# Altium schematic sheet Energia.SchDoc(Energia)
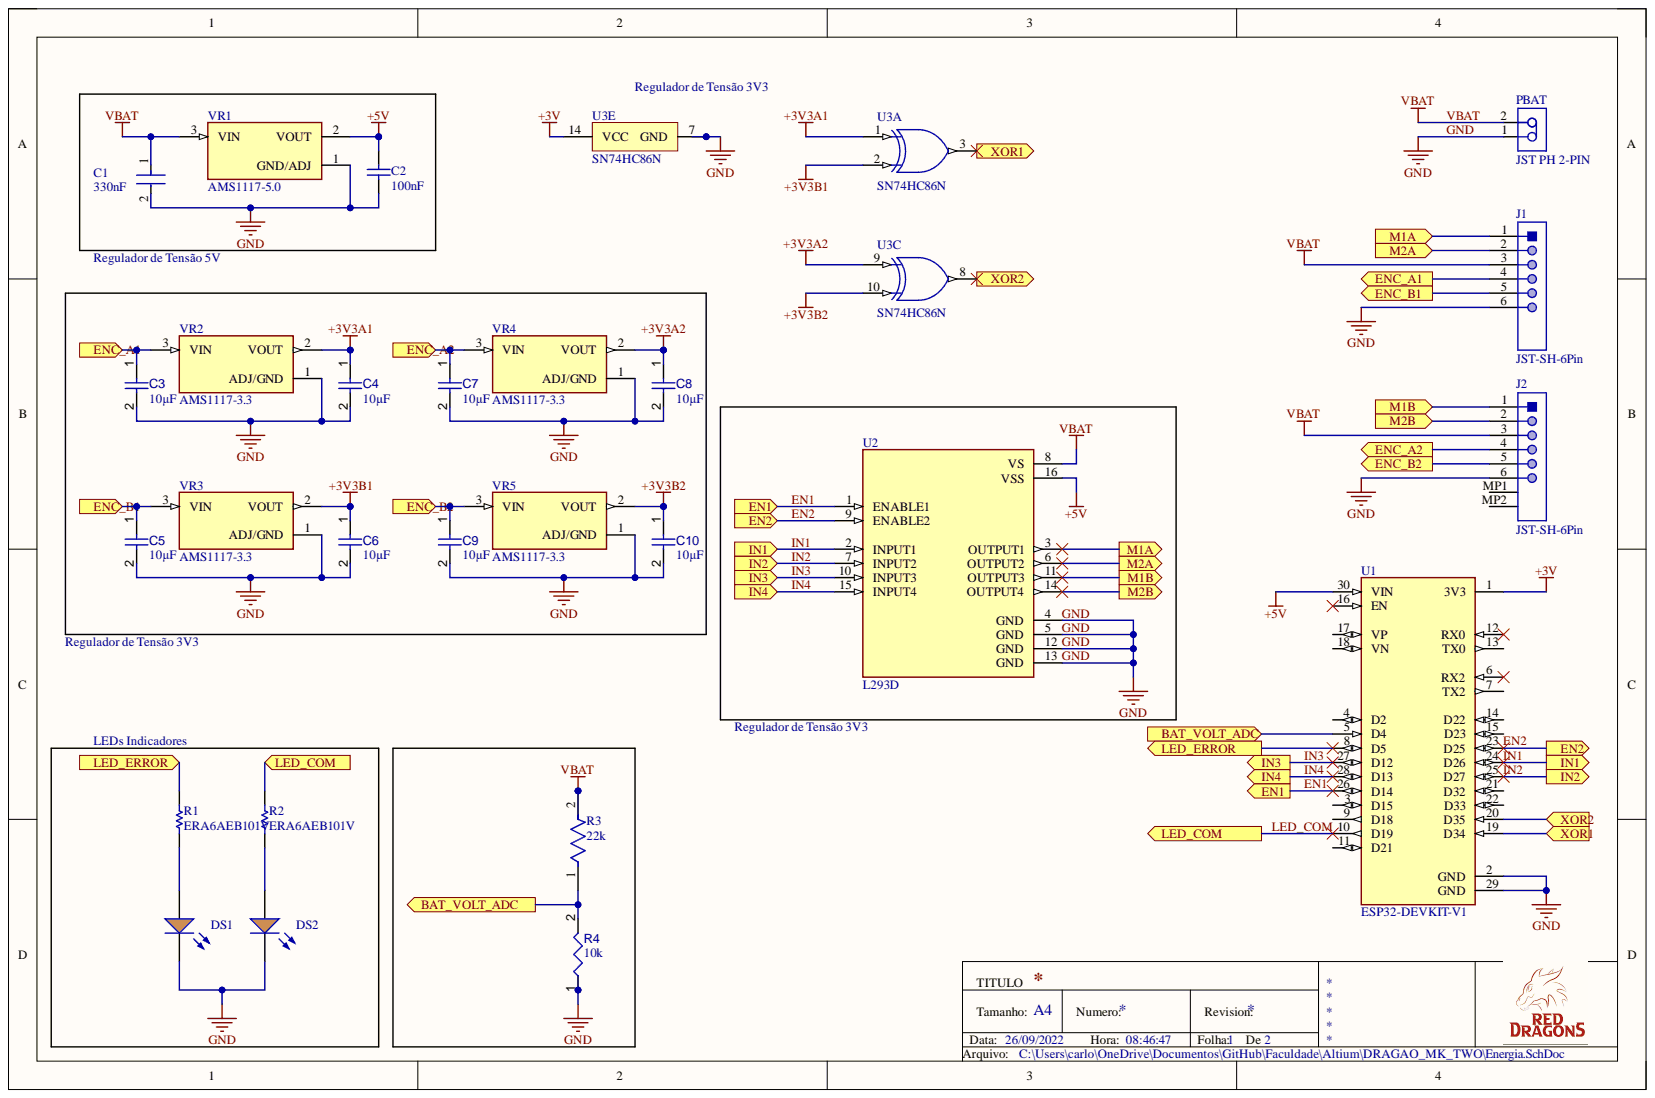
[diagram p0: Energia.SchDoc]

## Parts
C1  330nF  [FP-0805-L_2_01_0_2-W_1_25-IPC_B]
C2  100nF  [CAPC2013X140X50LL20T25]
C3  value 10µF  [CAPC2013X135X45NL10T25]  (hidden comment: Capacitor 10 uF +/- 10% 16 V 0805)
C4  value 10µF  [CAPC2013X135X45NL10T25]  (hidden comment: Capacitor 10 uF +/- 10% 16 V 0805)
C5  value 10µF  [CAPC2013X135X45NL10T25]  (hidden comment: Capacitor 10 uF +/- 10% 16 V 0805)
C6  value 10µF  [CAPC2013X135X45NL10T25]  (hidden comment: Capacitor 10 uF +/- 10% 16 V 0805)
C7  value 10µF  [CAPC2013X135X45NL10T25]  (hidden comment: Capacitor 10 uF +/- 10% 16 V 0805)
C8  value 10µF  [CAPC2013X135X45NL10T25]  (hidden comment: Capacitor 10 uF +/- 10% 16 V 0805)
C9  value 10µF  [CAPC2013X135X45NL10T25]  (hidden comment: Capacitor 10 uF +/- 10% 16 V 0805)
C10  value 10µF  [CAPC2013X135X45NL10T25]  (hidden comment: Capacitor 10 uF +/- 10% 16 V 0805)
DS1  LTST-C190KFKT  [LTST-C190KFKT_V]  (value not drawn)
DS2  LTST-C190KFKT  [LTST-C190KFKT_V]  (value not drawn)
J1  JST-SH-6Pin  [JST-SM06B-SRSS-TB_V]
J2  JST-SH-6Pin  [JST-SM06B-SRSS-TB_V]
PBAT  JST PH 2-PIN  Manufacturer: JST  [JST-B2B-PH-K-S_V]
R1  ERA6AEB101V  [RESC2013X60X40ML20T25]
R2  ERA6AEB101V  [RESC2013X60X40ML20T25]
R3  22k  [FP-CR0805-IPC_B]
R4  value 10k  [RESC2013X50X35ML15T15]  (hidden comment: Resistor 10k +/-1% 0805 125 mW)
U1  ESP32-DEVKIT-V1  [MODULE_ESP32_DEVKIT_V1]
U2  L293D  [DIP880W50P254L2000H510Q16]
U3  SN74HC86N  [N0014A]  (parts A,C,E)
VR1  AMS1117-5.0  [SOT229P700X180-4N]
VR2  AMS1117-3.3  [SOT229P700X180-4N]
VR3  AMS1117-3.3  [SOT229P700X180-4N]
VR4  AMS1117-3.3  [SOT229P700X180-4N]
VR5  AMS1117-3.3  [SOT229P700X180-4N]

## Ports
BAT_VOLT_ADC, EN1, EN2, ENC_A1, ENC_A2, ENC_B1, ENC_B2, IN1, IN2, IN3, IN4, LED_COM, LED_ERROR, M1A, M1B, M2A, M2B, XOR1, XOR2

## Nets
+3V: U1.1(3V3) U3.14(VCC)
+3V3A1: C4.1 U3.1 VR2.2
+3V3A2: C8.1 U3.9 VR4.2
+3V3B1: C6.1 U3.2 VR3.2
+3V3B2: C10.1 U3.10 VR5.2
+5V: C2.2 U1.30(VIN) U2.16(VSS) VR1.2
BAT_VOLT_ADC: R3.1 R4.2 U1.5(D4) ; port BAT_VOLT_ADC
EN1: U1.26(D14) U2.1(ENABLE1) ; port EN1
EN2: U1.23(D25) U2.9(ENABLE2) ; port EN2
ENC_A1: C3.1 J1.4 VR2.3 ; port ENC_A1
ENC_A2: C7.1 J2.4 VR4.3 ; port ENC_A2
ENC_B1: C5.1 J1.5 VR3.3 ; port ENC_B1
ENC_B2: C9.1 J2.5 VR5.3 ; port ENC_B2
GND: C1.2 C2.1 C3.2 C4.2 C5.2 C6.2 C7.2 C8.2 C9.2 C10.2 DS1.2 DS2.2 J1.6 J2.6 PBAT.1 R4.1 U1.2(GND) U1.29(GND) U2.4(GND) U2.5(GND) U2.12(GND) U2.13(GND) U3.7(GND) VR1.1 VR2.1 VR3.1 VR4.1 VR5.1
IN1: U1.24(D26) U2.2(INPUT1) ; port IN1
IN2: U1.25(D27) U2.7(INPUT2) ; port IN2
IN3: U1.27(D12) U2.10(INPUT3) ; port IN3
IN4: U1.28(D13) U2.15(INPUT4) ; port IN4
LED_COM: R2.2 U1.10(D19) ; port LED_COM
LED_ERROR: R1.2 U1.8(D5) ; port LED_ERROR
M1A: J1.1 U2.3(OUTPUT1) ; port M1A
M1B: J2.1 U2.11(OUTPUT3) ; port M1B
M2A: J1.2 U2.6(OUTPUT2) ; port M2A
M2B: J2.2 U2.14(OUTPUT4) ; port M2B
VBAT: C1.1 J1.3 J2.3 PBAT.2 R3.2 U2.8(VS) VR1.3
XOR1: U1.19(D34) U3.3 ; port XOR1
XOR2: U1.20(D35) U3.8 ; port XOR2
NetDS1_1: DS1.1 R1.1
NetDS2_1: DS2.1 R2.1

Not connected: J2 MP1 MP2; U1 3(D15) 4(D2) 6(RX2) 7(TX2) 9(D18) 11(D21) 12(RX0) 13(TX0) 14(D22) 15(D23) 16(EN) 17(VP) 18(VN) 21(D32) 22(D33)

## Library data of the parts
C1 = CMP-2007-04657-2: General Purpose Ceramic Capacitor, 0805, 330nF, 10%, X7R, 15%, 16V; Dissipation Factor: 3.5%; Insulation Resistance: 100GR; Capacitance: 330nF; Case Code (Imperial): 0805; Case Code (Metric): 2012; Case/Package: 0805; Component Kind: Standard; Construction: Flat; Contact Plating: Tin; Depth: 1.25mm; Dielectric: X7R; Height: 1.4mm; Lead Free: Lead Free; Length: 2.01mm; Library Name: Altium Content Vault; Max Operating Temperature: 125°C; Min Operating Temperature: -55°C; Mount: Surface Mount; Number of Pins: 2; Package Quantity: 1; Packaging: Tape and Reel; Radiation Hardening: No; REACH SVHC: No SVHC; RoHS Compliant: Yes; Series: -; Size: 1.4mm; Termination: SMD/SMT; Thickness: 0.055inch; Tolerance: 10%; Voltage: 16V; Voltage Rating: 16V; Voltage Rating (DC): 16V; Width: 1.25mm
C2 = CMP-2007-04746-1: Manufacturer: AVX Interconnect / Elco; Part Number: 08055C104K4T4A; Component Kind: Standard; Library Name: Altium Content Vault
C3-C10 = CMP-001-00015-6: Chip Capacitor, 10 uF, +/- 10%, 16 V, 0805 (2012 Metric); Capacitor Type: Ceramic; Voltage Rating: 16V; Case/Package: 0805; Component Kind: Standard; Max Operating Temperature: 85°C; Min Operating Temperature: -55°C; Mounting Technology: SM; Pins: 2; RoHS Compliant: True; Tolerance: 10%; Library Name: Ufscar - Universidade Federal de São Carlos
DS1 DS2 = CMP-007-00023-2: LED Uni-Color Orange, 75 mW, 30 mA, -35 to 85 degC, 2-Pin SMD, RoHS, Tape and Reel; Manufacturer: Lite-On; Forward Current: 20mA; Forward Voltage: 2V; Lens Style: Diffused; Luminous Intensity: 90mcd; Power: 75mW; Viewing Angle: 130degrees; Case/Package: 0603; Colour: Orange; Component Kind: Standard; Datasheet URL: http://optoelectronics.liteon.com/upload/download/DS-22-99-0186/S_110_LTST-C190KFKT.pdf; Datasheet Version: Rev. I, 09/2011; Illumination Colour: Orange; Manufacturer URL: http://www.us.liteon.com/; Max Operating Temperature: 85°C; Min Operating Temperature: -55°C; Mounting Technology: SM; Nominal Vf: 2V; Package Description: 2-Pin Surface Mount Device, Body 1.6 x 0.8 mm; Package Quantity: 3000; Package Reference: C190KFKT; Packaging: TapeandReel; Pins: 2; RoHS Compliant: True; Library Name: Ufscar - Universidade Federal de São Carlos
J1 J2 = CMP-2000-07080-1: Male Header, Pitch 1 mm, 1 x 6 Position, Height 2.95 mm, -25 to 85 degC, RoHS, Tape and Reel; Manufacturer: JST; No of Positions: 6; Pitch: 1mm; Style/Orientation: RightAngleHeader/Plug; Component Kind: Standard; Datasheet URL: https://datasheet.ciiva.com/26894/getdatasheetpartid-708885-26894671.pdf; Datasheet Version: 11/2010; Library Name: Altium Content Vault; Manufacturer URL: http://www.jst.com/home2.html; Mounting Technology: SurfaceMount; Package Description: Male Header, Pitch 1 mm, 1 X 6 Position, Height 2.9 mm; Package Reference: SM06B-SRSS-TB; Termination: Solder; Type: ShroudedHeader
PBAT = CMP-2000-05162-1: Male Header, Pitch 2 mm, 1 x 2 Position, Height 6 mm, Tail Length 3.4 mm, -25 to 85 degC, RoHS, Bulk; No of Positions: 2; Pitch: 2mm; Style/Orientation: StraightHeader/Plug; Component Kind: Standard; Datasheet URL: http://datasheet.octopart.com/B2B-PH-K-S%28LF%29%28SN%29-JST-datasheet-8324864.pdf; Datasheet Version: Nov-08; Library Name: Altium Content Vault; Manufacturer URL: http://www.jst.com/home2.html; Termination: Crimp; Type: ShroudedHeader
R1 R2 = CMP-2001-00580-1: Manufacturer: Panasonic; Component Kind: Standard; Library Name: Altium Content Vault; Part Number: ERA6AEB101V
R3 = CMP-07231-001007-1: Thick Film Chip Resistors 0805 22kΩ 0.125W 5% 200ppm/°C; Power Rating: 125mW; Resistance: 22kR; Voltage Rating: 150V; Case Code (Imperial): 0805; Case Code (Metric): 2012; Case/Package: 0805; Component Kind: Standard; Composition: Thick Film; Contact Plating: Tin; Depth: 1.25mm; Height: 0.5mm; Length: 2mm; Library Name: Altium Content Vault; Max Operating Temperature: 155°C; Military Standard: Not; Min Operating Temperature: -55°C; Mount: Surface Mount; Package Quantity: 5000; Packaging: Tape and Reel; Radiation Hardening: No; Temperature Coefficient: 200ppm/°C; Termination: SMD/SMT; Thickness: 508um; Tolerance: 5%; Width: 1.25mm
R4 = CMP-009-00018-5: Chip Resistor, 10 KOhm, +/- 1%, 125 mW, -55 to 155 degC, 0805 (2012 Metric); Power: 125mW; Voltage Rating: 150V; Case/Package: 0805; Component Kind: Standard; Max Operating Temperature: 155°C; Min Operating Temperature: -55°C; Mounting Technology: SM; Pins: 2; RoHS Compliant: True; Tolerance: 1%; Library Name: Ufscar - Universidade Federal de São Carlos
U1 = ESP32-DEVKIT-V1: Dual core, Wi-Fi: 2.4 GHz up to 150 Mbits/s,BLE (Bluetooth Low Energy) and legacy Bluetooth, 32 bits, Up to 240 MHz; MF: {'manufacturer': u'Do it'}; Availability: Unavailable; Component Kind: Standard; MP: ESP32-DEVKIT-V1; Package: None; Price: None; Library Name: RED_Dragons.IntLib
U2 = L293D: 4-Channel Motor Driver 24V 16-Pin Power PDIP Tube; MF: {'manufacturer': u'STMicroelectronics'}; Availability: Unavailable; Component Kind: Standard; MP: L293D; Package: None; Price: None; Library Name: RED_Dragons.IntLib
U3 = CMP-024-00000-1: 4-ch, 2-input, 2-V to 6-V XOR (exclusive OR) gates 14-PDIP -40 to 85; Component Kind: Standard; Library Name: Ufscar - Universidade Federal de São Carlos
VR1 = AMS1117-5.0: MF: {'manufacturer': u'ams'}; Availability: Unavailable; Component Kind: Standard; MP: AMS1117-5.0; Package: None; Price: None
VR2-VR5 = AMS1117-3.3: Sot223/Pkg 1-Amp 3.3-Volt Low Drop Out Voltage Regulatator; MF: {'manufacturer': u'Advanced Monolithic Systems'}; Availability: Unavailable; Component Kind: Standard; MP: AMS1117-3.3; Package: None; Price: None; Library Name: RED_Dragons.IntLib
